# Altium schematic sheet Sche_mono.SchDoc(Sche_mono)
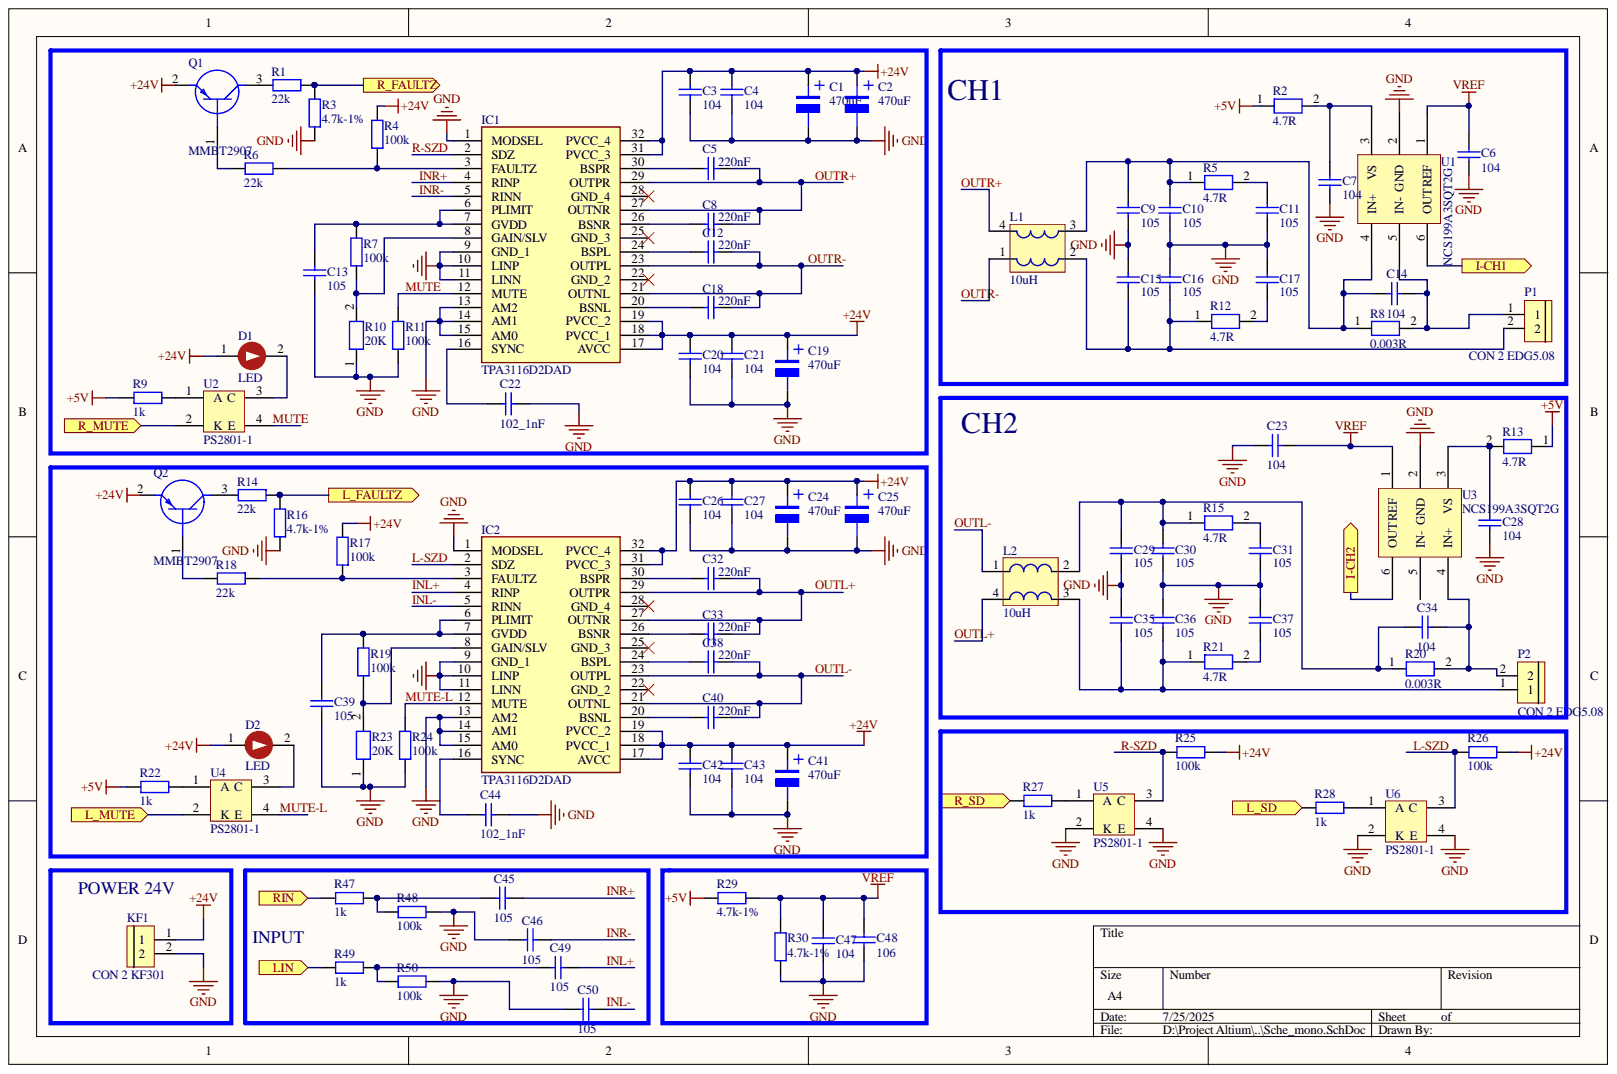
[diagram p0: Sche_mono.SchDoc]

## Parts
C1  470uF  [tu nhom 10 (G)]
C2  470uF  [tu nhom 10 (G)]
C3  104  [tu 0603]
C4  104  [tu 0603]
C5  220nF  [Tu 0603]
C6  104  [tu 0603]
C7  104  [tu 0603]
C8  220nF  [Tu 0603]
C9  105  [tu 0603]
C10  105  [tu 0603]
C11  105  [tu 0603]
C12  220nF  [Tu 0603]
C13  105  [tu 0603]
C14  104  [tu 0603]
C15  105  [tu 0603]
C16  105  [tu 0603]
C17  105  [tu 0603]
C18  220nF  [Tu 0603]
C19  470uF  [tu nhom 10 (G)]
C20  104  [tu 0603]
C21  104  [tu 0603]
C22  102_1nF  [tu 0603]
C23  104  [tu 0603]
C24  470uF  [tu nhom 10 (G)]
C25  470uF  [tu nhom 10 (G)]
C26  104  [tu 0603]
C27  104  [tu 0603]
C28  104  [tu 0603]
C29  105  [tu 0603]
C30  105  [tu 0603]
C31  105  [tu 0603]
C32  220nF  [Tu 0603]
C33  220nF  [Tu 0603]
C34  104  [tu 0603]
C35  105  [tu 0603]
C36  105  [tu 0603]
C37  105  [tu 0603]
C38  220nF  [Tu 0603]
C39  105  [tu 0603]
C40  220nF  [Tu 0603]
C41  470uF  [tu nhom 10 (G)]
C42  104  [tu 0603]
C43  104  [tu 0603]
C44  102_1nF  [tu 0603]
C45  105  [tu 0603]
C46  105  [tu 0603]
C47  104  [tu 0603]
C48  106  [tu 0805]
C49  105  [tu 0603]
C50  105  [tu 0603]
D1  LED  [LED0603]
D2  LED  [LED0603]
IC1  TPA3116D2DAD  [SOP65P810X120-32N]
IC2  TPA3116D2DAD  [SOP65P810X120-32N]
KF1  CON 2 KF301  [Con 2 KF301 5.08]
L1  10uH  [CSD0910A 100M]
L2  10uH  [CSD0910A 100M]
P1  CON 2 EDG5.08  [CON 2 2EDG5.08]
P2  CON 2 EDG5.08  [CON 2 2EDG5.08]
Q1  MMBT2907  [MMBT2907]
Q2  MMBT2907  [MMBT2907]
R1  22k  [tro smd 0603]
R2  4.7R  [TRO SMD 0603]
R3  4.7k-1%  [tro smd 0603]
R4  100k  [tro smd 0603]
R5  4.7R  [TRO SMD 0603]
R6  22k  [tro smd 0603]
R7  100k  [tro smd 0603]
R8  0.003R  [Tro SMD 1206]
R9  1k  [tro smd 0603]
R10  20K  [RESC1608X55N]
R11  100k  [tro smd 0603]
R12  4.7R  [TRO SMD 0603]
R13  4.7R  [TRO SMD 0603]
R14  22k  [tro smd 0603]
R15  4.7R  [TRO SMD 0603]
R16  4.7k-1%  [tro smd 0603]
R17  100k  [tro smd 0603]
R18  22k  [tro smd 0603]
R19  100k  [tro smd 0603]
R20  0.003R  [Tro SMD 1206]
R21  4.7R  [TRO SMD 0603]
R22  1k  [tro smd 0603]
R23  20K  [RESC1608X55N]
R24  100k  [tro smd 0603]
R25  100k  [tro smd 0603]
R26  100k  [tro smd 0603]
R27  1k  [tro smd 0603]
R28  1k  [tro smd 0603]
R29  4.7k-1%  [tro smd 0603]
R30  4.7k-1%  [tro smd 0603]
R47  1k  [tro smd 0603]
R48  100k  [tro smd 0603]
R49  1k  [tro smd 0603]
R50  100k  [tro smd 0603]
U1  NCS199A3SQT2G  [NCS199A2SQT2G]
U2  PS2801-1  [PS2801]
U3  NCS199A3SQT2G  [NCS199A2SQT2G]
U4  PS2801-1  [PS2801]
U5  PS2801-1  [PS2801]
U6  PS2801-1  [PS2801]

## Ports
I-CH1, I-CH2, L_FAULTZ, L_MUTE, L_SD, LIN, R_FAULTZ, R_MUTE, R_SD, RIN

## Nets
+5V: R2.1 R9.1 R13.1 R22.1 R29.1
+24V: C1.1 C2.1 C3.1 C4.1 C19.1 C20.1 C21.1 C24.1 C25.1 C26.1 C27.1 C41.1 C42.1 C43.1 D1.1 D2.1 IC1.17(AVCC) IC1.18(PVCC_1) IC1.19(PVCC_2) IC1.31(PVCC_3) IC1.32(PVCC_4) IC2.17(AVCC) IC2.18(PVCC_1) IC2.19(PVCC_2) IC2.31(PVCC_3) IC2.32(PVCC_4) KF1.1 Q1.2 Q2.2 R4.2 R17.2 R25.1 R26.1
GND: C1.2 C2.2 C3.2 C4.2 C6.2 C7.2 C9.2 C10.2 C11.2 C13.2 C15.1 C16.1 C17.1 C19.2 C20.2 C21.2 C22.2 C23.1 C24.2 C25.2 C26.2 C27.2 C28.2 C29.2 C30.2 C31.2 C35.1 C36.1 C37.1 C39.2 C41.2 C42.2 C43.2 C44.2 C46.1 C47.2 C48.2 C50.1 IC1.1(MODSEL) IC1.9(GND_1) IC1.10(LINP) IC1.11(LINN) IC1.13(AM2) IC1.14(AM1) IC1.15(AM0) IC2.1(MODSEL) IC2.9(GND_1) IC2.10(LINP) IC2.11(LINN) IC2.13(AM2) IC2.14(AM1) IC2.15(AM0) KF1.2 R3.1 R10.1 R11.1 R16.1 R23.1 R24.1 R30.1 R48.2 R50.2 U1.2(GND) U3.2(GND) U5.2(K) U5.4(E) U6.2(K) U6.4(E)
VREF: C6.1 C23.2 C47.1 C48.1 R29.2 R30.2 U1.1(REF) U3.1(REF)
I-CH1: U1.6(OUT) ; port I-CH1
I-CH2: U3.6(OUT) ; port I-CH2
INL+: C49.2 IC2.4(RINP)
INL-: C50.2 IC2.5(RINN)
INR+: C45.2 IC1.4(RINP)
INR-: C46.2 IC1.5(RINN)
L-SZD: IC2.2(SDZ) R26.2 U6.3(C)
L_FAULTZ: R14.1 R16.2 ; port L_FAULTZ
L_MUTE: U4.2(K) ; port L_MUTE
L_SD: R28.1 ; port L_SD
LIN: R49.1 ; port LIN
MUTE: IC1.12(MUTE) R11.2 U2.4(E)
MUTE-L: IC2.12(MUTE) R24.2 U4.4(E)
OUTL+: C32.1 C33.1 IC2.27(OUTNR) IC2.29(OUTPR) L2.4
OUTL-: C38.1 C40.1 IC2.21(OUTNL) IC2.23(OUTPL) L2.1
OUTR+: C5.1 C8.1 IC1.27(OUTNR) IC1.29(OUTPR) L1.4
OUTR-: C12.1 C18.1 IC1.21(OUTNL) IC1.23(OUTPL) L1.1
R-SZD: IC1.2(SDZ) R25.2 U5.3(C)
R_FAULTZ: R1.1 R3.2 ; port R_FAULTZ
R_MUTE: U2.2(K) ; port R_MUTE
R_SD: R27.1 ; port R_SD
RIN: R47.1 ; port RIN
NetC5_2: C5.2 IC1.30(BSPR)
NetC7_1: C7.1 R2.2 U1.3(VS)
NetC8_2: C8.2 IC1.26(BSNR)
NetC9_1: C9.1 C10.1 C14.1 L1.3 R5.1 R8.1 U1.4(IN+)
NetC11_1: C11.1 R5.2
NetC12_2: C12.2 IC1.24(BSPL)
NetC13_1: C13.1 IC1.6(PLIMIT) IC1.7(GVDD) R7.2
NetC14_2: C14.2 P1.1 R8.2 U1.5(IN-)
NetC15_2: C15.2 C16.2 L1.2 P1.2 R12.1
NetC17_2: C17.2 R12.2
NetC18_2: C18.2 IC1.20(BSNL)
NetC22_1: C22.1 IC1.16(SYNC)
NetC28_1: C28.1 R13.2 U3.3(VS)
NetC29_1: C29.1 C30.1 C34.2 L2.2 R15.1 R20.1
NetC31_1: C31.1 R15.2
NetC32_2: C32.2 IC2.30(BSPR)
NetC33_2: C33.2 IC2.26(BSNR)
NetC34_1: C34.1 P2.2 R20.2 U3.4(IN+)
NetC35_2: C35.2 C36.2 L2.3 P2.1 R21.1
NetC37_2: C37.2 R21.2
NetC38_2: C38.2 IC2.24(BSPL)
NetC39_1: C39.1 IC2.6(PLIMIT) IC2.7(GVDD) R19.2
NetC40_2: C40.2 IC2.20(BSNL)
NetC44_1: C44.1 IC2.16(SYNC)
NetC45_1: C45.1 R47.2 R48.1
NetC49_1: C49.1 R49.2 R50.1
NetD1_2: D1.2 U2.3(C)
NetD2_2: D2.2 U4.3(C)
NetIC1_3: IC1.3(FAULTZ) R4.1 R6.2
NetIC1_8: IC1.8(GAIN/SLV) R7.1 R10.2
NetIC2_3: IC2.3(FAULTZ) R17.1 R18.1
NetIC2_8: IC2.8(GAIN/SLV) R19.1 R23.2
NetQ1_1: Q1.1 R6.1
NetQ1_3: Q1.3 R1.2
NetQ2_1: Q2.1 R18.2
NetQ2_3: Q2.3 R14.2
NetR9_2: R9.2 U2.1(A)
NetR22_2: R22.2 U4.1(A)
NetR27_2: R27.2 U5.1(A)
NetR28_2: R28.2 U6.1(A)

Not connected: IC1 22(GND_2) 25(GND_3) 28(GND_4); IC2 22(GND_2) 25(GND_3) 28(GND_4); U3 5(IN-)

## Library data of the parts
C1 C2 C19 C24 C25 C41 = Tu Nhom 470uF 35V: Dán; Ten_Linh_Kien: Tụ Nhôm 470uF 35V 10x10.5MM; So_Chan: 2; Ma_Hang: MH004418; Don_Vi: Con; Library Name: Tu dien.SchLib; Component Kind: Standard; Chung_Loai: Dán
C3 C4 C6 C7 C14 C20 C21 C23 C26-C28 C34 C42 C43 C47 = Tu 0603 104 50V 10%: Dán; Ten_Linh_Kien: Tụ 0603 104-100nF 10% 50V; So_Chan: 2; Ma_Hang: MH004568; Don_Vi: Con; Library Name: Tu dien.SchLib; Component Kind: Standard; Chung_Loai: Dán
C5 C8 C12 C18 C32 C33 C38 C40 = 0603_220nF: Integrated Circuit; Manufacturer_Part_Number: ams1117-3.3v; Manufacturer_Name: Advanced Monolithic Systems; Library Name: test.SchLib; Height: 1.8mm; Datasheet Link: http://www.advanced-monolithic.com/pdf/ds1117.pdf; Component Kind: Standard
C9-C11 C13 C15-C17 C29-C31 C35-C37 C39 C45 C46 C49 C50 = Tu 0603 105 50V 10%: Dán; Ten_Linh_Kien: Tụ 0603 105-1uF 50V 10%; So_Chan: 2; Ma_Hang: MH004569; Don_Vi: Con; Library Name: Tu dien.SchLib; Component Kind: Standard; Chung_Loai: Dán
C22 = Tu 0603 102 50V 10%: Ten_Linh_Kien: Tụ 0603 102-1nF 10% 50V; So_Chan: 2; Ma_Hang: MH004566; Don_Vi: Con; Chung_Loai: Dán; Library Name: Tu dien.SchLib; Component Kind: Standard
C44 = Tu 0603 102 50V 10%: Dán; Ten_Linh_Kien: Tụ 0603 102-1nF 10% 50V; So_Chan: 2; Ma_Hang: MH004566; Don_Vi: Con; Library Name: Tu dien.SchLib; Component Kind: Standard; Chung_Loai: Dán
C48 = Tu 0805 106 16V 10%: Dán; Ten_Linh_Kien: Tụ 0805 106-10uF 10% 16V; So_Chan: 2; Ma_Hang: MH004553; Don_Vi: Con; Library Name: Tu dien.SchLib; Component Kind: Standard; Chung_Loai: Dán
D1 D2 = LED 0603 RED: LED RED SMD 0603; Library Name: test.SchLib; Component Kind: Standard
IC1 IC2 = TPA3116D2DAD: Integrated Circuit; Manufacturer_Name: Texas Instruments; Mouser Price/Stock: https://www.mouser.co.uk/ProductDetail/Texas-Instruments/TPA3116D2DAD?qs=3AcK5DacLbr72W1Ouw7j4Q%3D%3D; Mouser Part Number: 595-TPA3116D2DAD; Manufacturer_Part_Number: TPA3116D2DAD; Library Name: test.SchLib; Height: 1.2mm; Datasheet Link: http://www.ti.com/lit/ds/symlink/tpa3116d2.pdf; Component Kind: Standard; Arrow Price/Stock: https://www.arrow.com/en/products/tpa3116d2dad/texas-instruments?region=nac; Arrow Part Number: TPA3116D2DAD
KF1 = CON 2 KF301: Cắm; Ten_Linh_Kien: CON 2 KF301-2P 5.08MM Xanh Dương; Ma_Hang: MH000987; Library Name: Connector.SchLib; Don_Vi: Con; Component Kind: Standard; Chung_Loai: Cắm
L1 L2 = CSD0910A-100M: Inductor 10uH; Library Name: test.SchLib; Component Kind: Standard
P1 P2 = CON 2 EDG5.08: Cắm; Ten_Linh_Kien: CON 2 2EDG5.08-2P 5.08MM ( Xanh Cong); Ma_Hang: MH000759; Library Name: Connector.SchLib; Don_Vi: Con; Component Kind: Standard; Chung_Loai: Cắm
Q1 Q2 = MMBT2907: transistor; Library Name: test.SchLib; Component Kind: Standard
R1 R6 R14 R18 = Tro 0603 1% 22k: Dán; Ten_Linh_Kien: Trở 0603 1% 22k; So_Chan: 2; Ma_Hang: MH005794; Don_Vi: Con; Library Name: Dien Tro.SchLib; Component Kind: Standard; Chung_Loai: Dán
R2 R5 R12 R13 R15 R21 = R0603-4.7R-5%: Resistor 0603 4.7R 5%; Library Name: test.SchLib; Component Kind: Standard
R3 R16 R29 R30 = Tro 0603 5% 4.7k: Dán; Ten_Linh_Kien: Trở 0603 5% 4.7k; So_Chan: 2; Ma_Hang: MH006327; Don_Vi: Con; Library Name: Dien Tro.SchLib; Component Kind: Standard; Chung_Loai: Dán
R4 R7 R11 R17 R19 R24-R26 R48 R50 = Tro 0603 5% 100k: Dán; Ten_Linh_Kien: Trở 0603 5% 100k; So_Chan: 2; Ma_Hang: MH006314; Don_Vi: Con; Library Name: Dien Tro.SchLib; Component Kind: Standard; Chung_Loai: Dán
R8 R20 = R1206_0.003R: Resistor R1206 0.003R; Manufacturer_Part_Number: CR0603-FX-1202ELF; Manufacturer_Name: Bourns; Mouser Price/Stock: https://www.mouser.com/Search/Refine.aspx?Keyword=652-CR0603FX-1202ELF; Mouser Part Number: 652-CR0603FX-1202ELF; Library Name: test.SchLib; Height: 0.55mm; Datasheet Link: https://www.bourns.com/pdfs/chpreztr.pdf; Component Kind: Standard; Arrow Price/Stock: https://www.arrow.com/en/products/cr0603-fx-1202elf/bourns; Arrow Part Number: CR0603-FX-1202ELF
R9 R22 R27 R28 R47 R49 = Tro 0603 1% 1k: Dán; Ten_Linh_Kien: Trở 0603 1% 1k; So_Chan: 2; Ma_Hang: MH006334; Don_Vi: Con; Library Name: Dien Tro.SchLib; Component Kind: Standard; Chung_Loai: Dán
R10 R23 = R0603_20k_5%: Resistor 0603 20k; Manufacturer_Part_Number: CR0603-FX-1202ELF; Manufacturer_Name: Bourns; Mouser Price/Stock: https://www.mouser.com/Search/Refine.aspx?Keyword=652-CR0603FX-1202ELF; Mouser Part Number: 652-CR0603FX-1202ELF; Library Name: test.SchLib; Height: 0.55mm; Datasheet Link: https://www.bourns.com/pdfs/chpreztr.pdf; Component Kind: Standard; Arrow Price/Stock: https://www.arrow.com/en/products/cr0603-fx-1202elf/bourns; Arrow Part Number: CR0603-FX-1202ELF
U1 U3 = NCS199A3SQT2G: Library Name: test.SchLib; Description: NCS199A3SQT2G; Component Kind: Standard
U2 U4-U6 = PS2801-1: Library Name: test.SchLib; Component Kind: Standard
